# Altium schematic sheet [03] - ARDUINO NANO 33 IOT.SchDoc
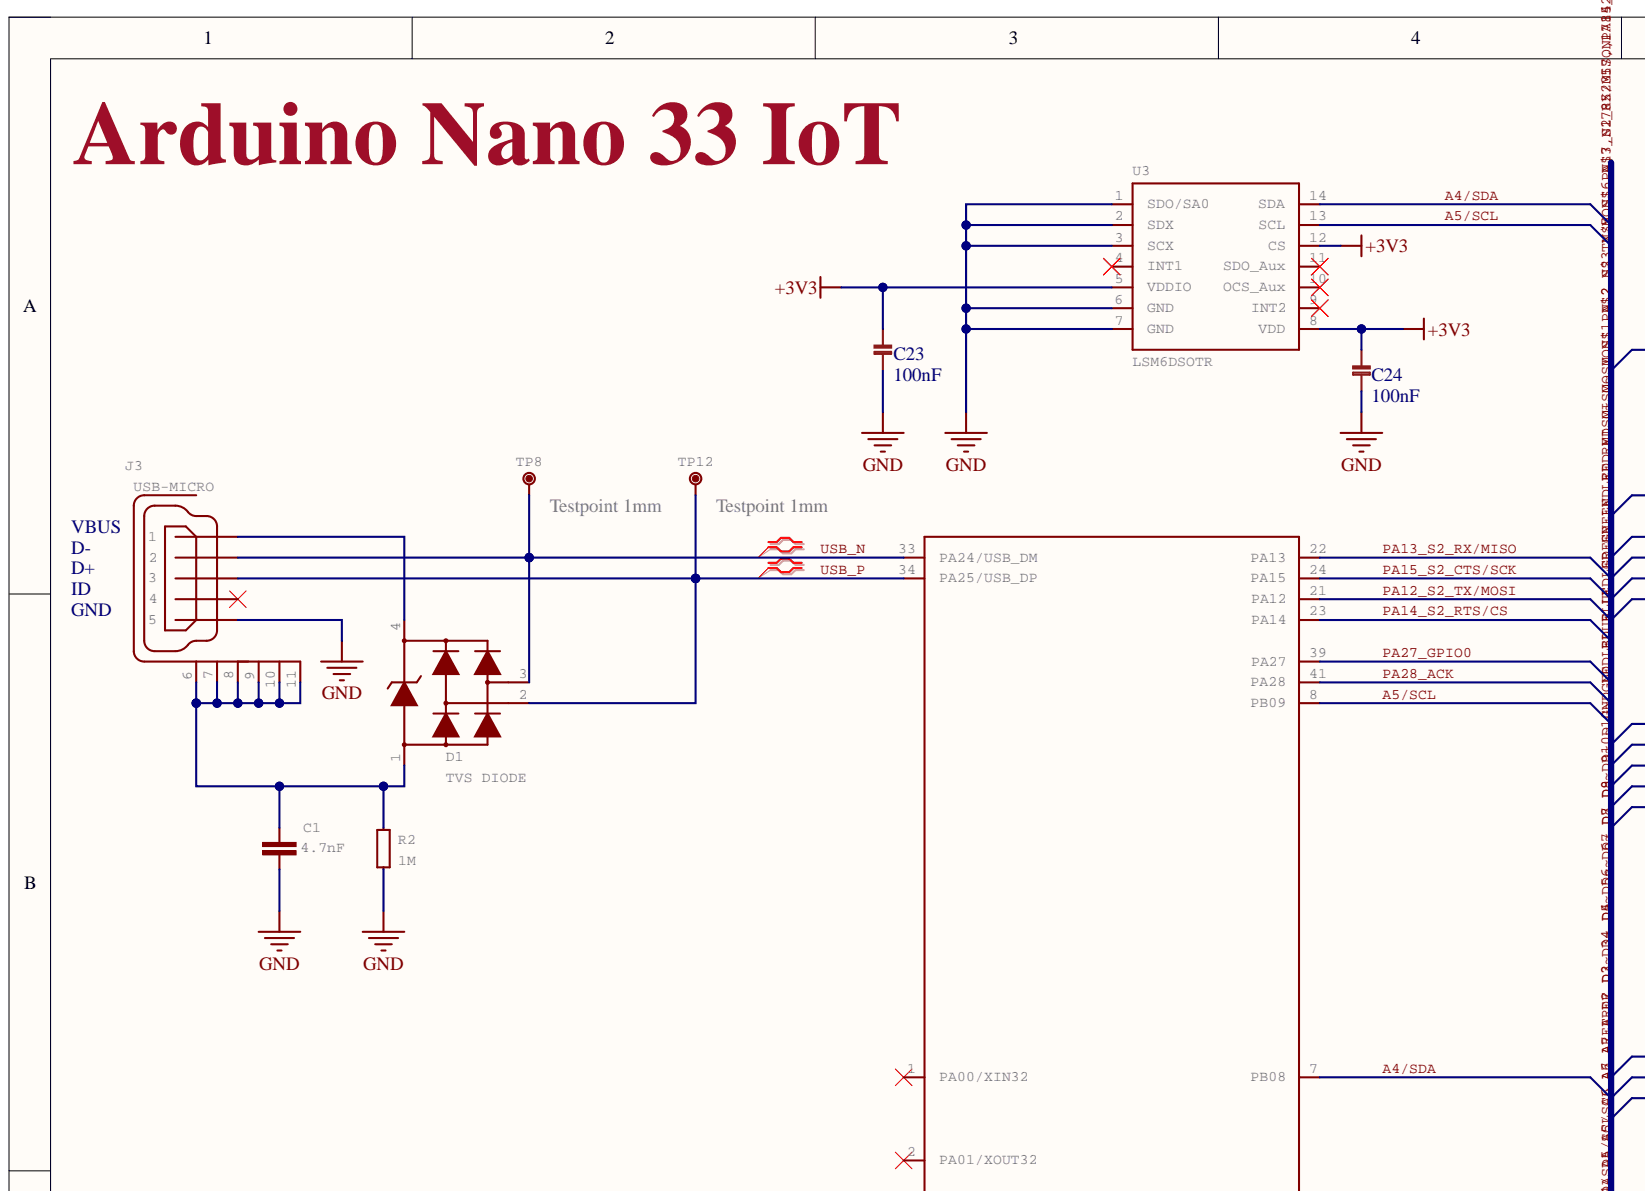
[diagram p0: [03] - ARDUINO NANO 33 IOT.SchDoc, top left]
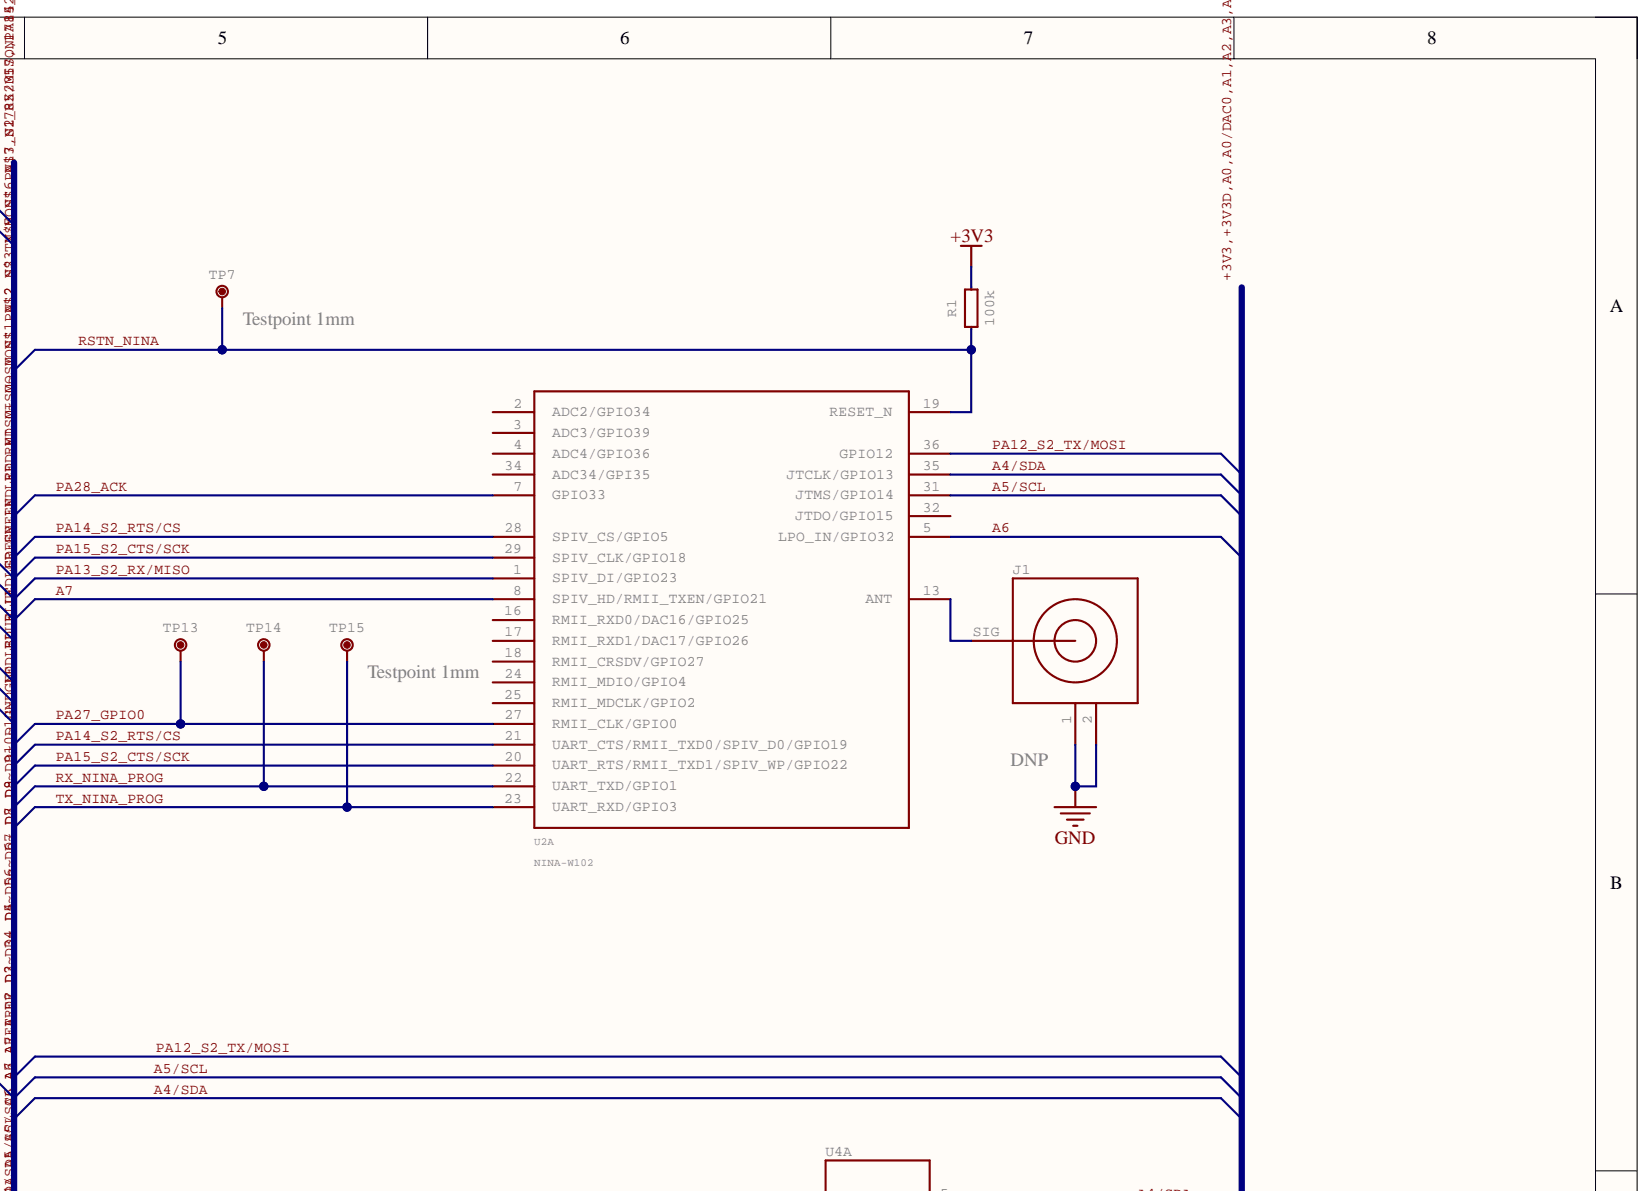
[diagram p1: [03] - ARDUINO NANO 33 IOT.SchDoc, top right]
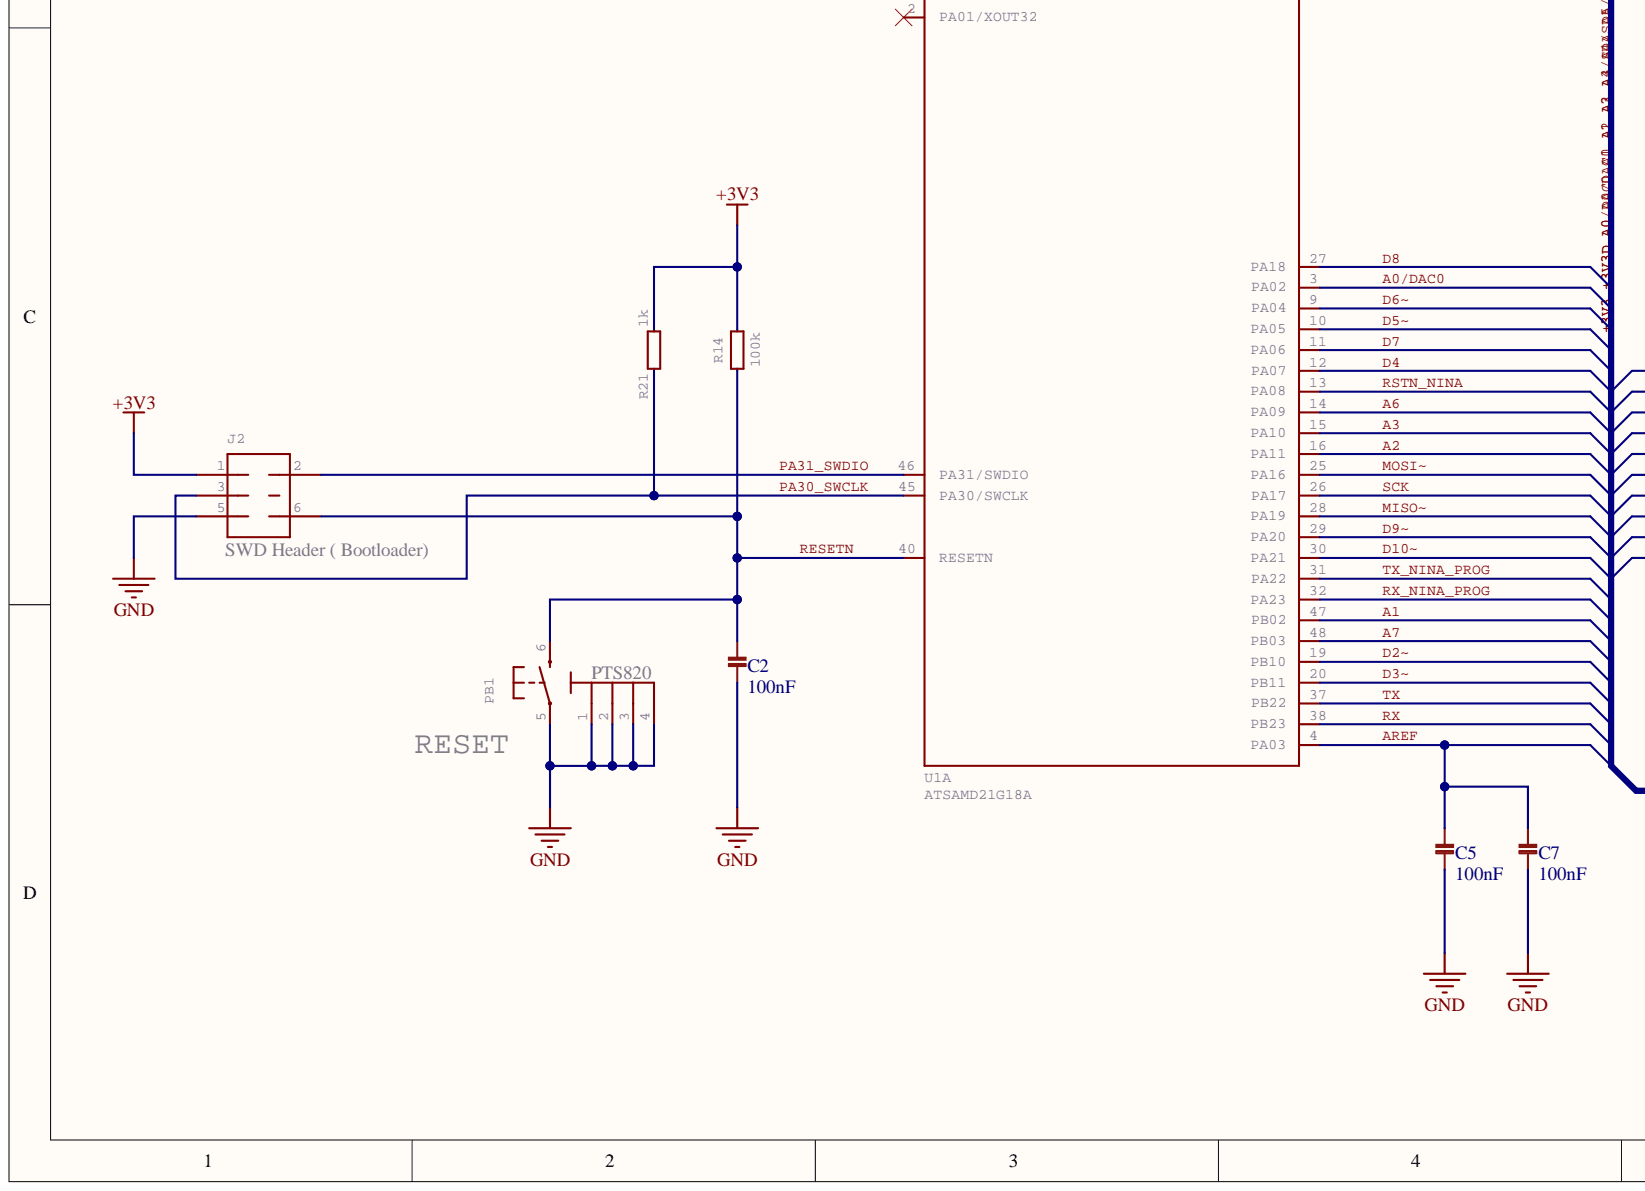
[diagram p2: [03] - ARDUINO NANO 33 IOT.SchDoc, bottom left]
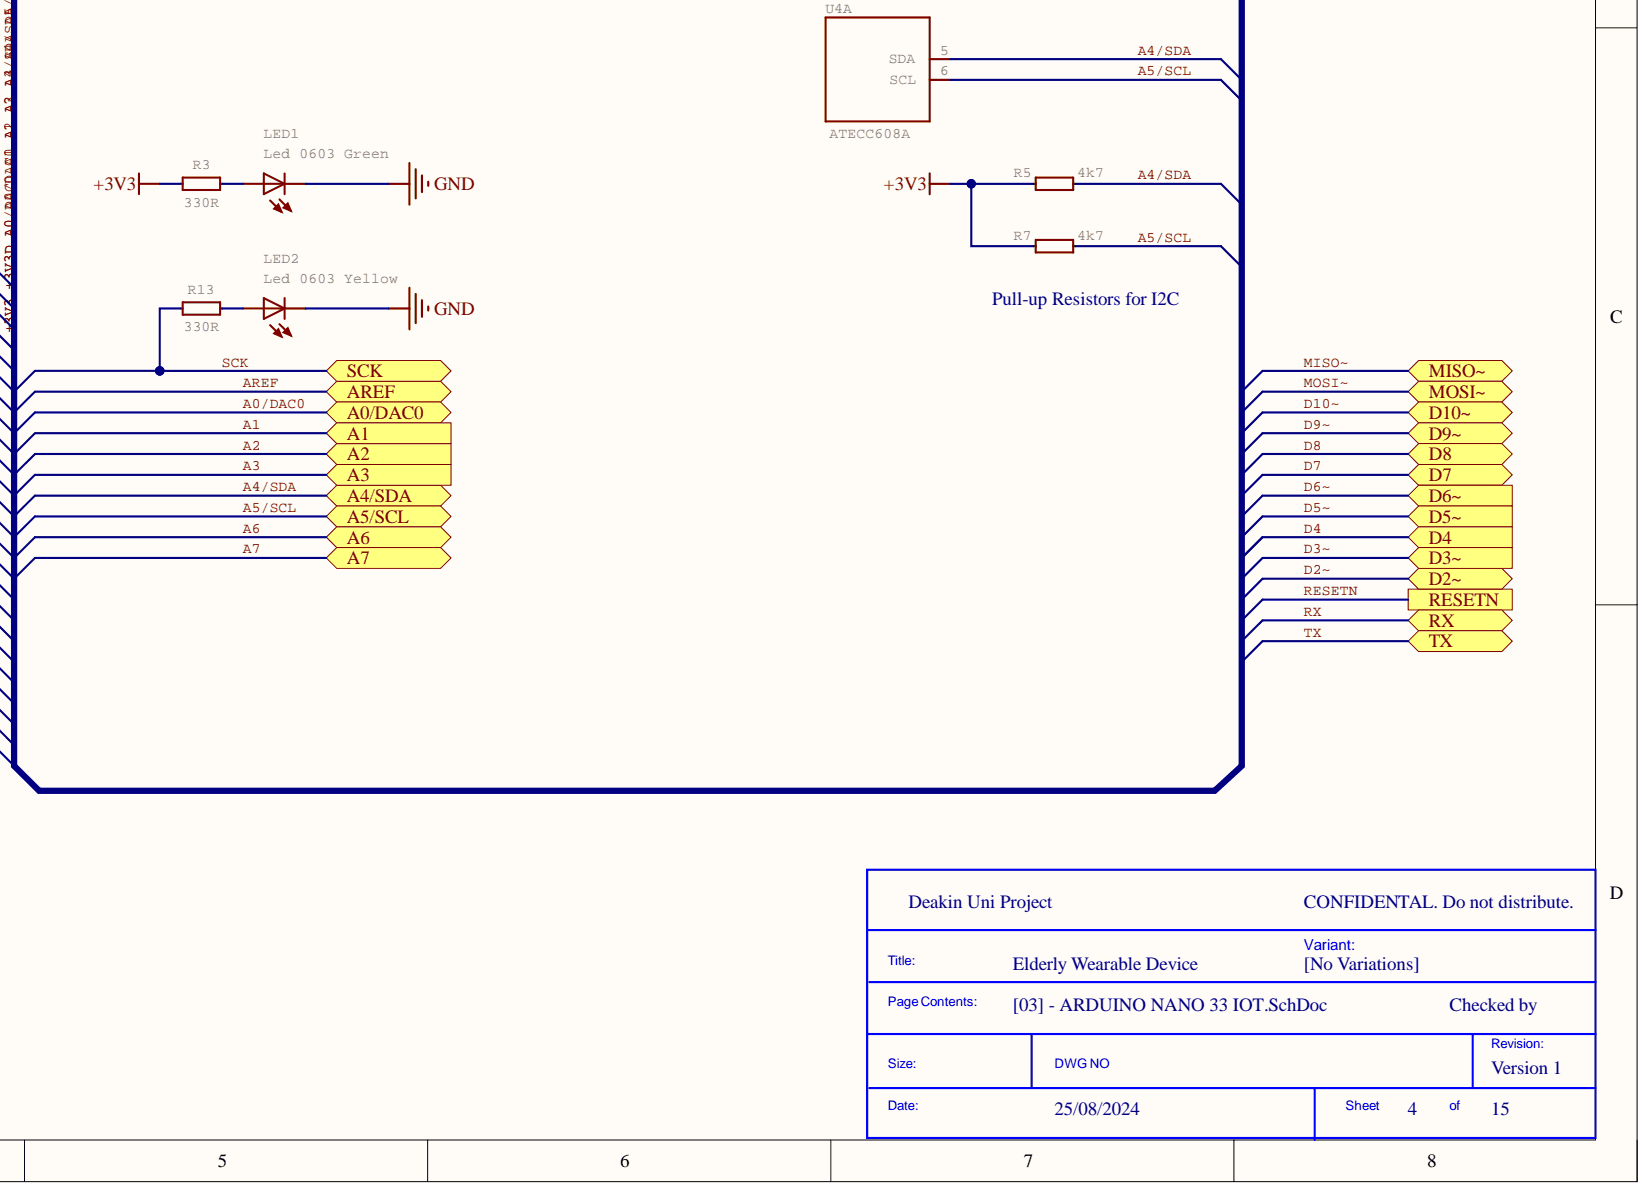
[diagram p3: [03] - ARDUINO NANO 33 IOT.SchDoc, bottom right]

## Parts
C1  4.7nF  [C_0805]
C2  100nF  [C_0402]
C5  100nF  [C_0402]
C7  100nF  [C_0402]
C23  100nF  [C_0402]
C24  100nF  [C_0402]
D1  TVS DIODE  [Tvs_Diode]
J1  DNP  [Antenna]  (no BOM)
J2  SWD Header ( Bootloader)  [SWD Header ( Bootloader)]
J3  USB-MICRO  [USB MIRCO]
LED1  Led 0603 Green  Package: 0603  [LED_0603_GREEN]
LED2  Led 0603 Yellow  Package: 0603  [LED_0603_YELLOW]
PB1  PTS820  [Tactile Switch]
R1  100k  [R_0402]
R2  1M  [R_0402]
R3  330R  [R_0402]
R5  4k7  [R_0402]
R7  4k7  [R_0402]
R13  330R  [R_0402]
R14  100k  [R_0402]
R21  1k  [R_0402]
TP7  Testpoint 1mm  [Testpoint_1mm]
TP8  Testpoint 1mm  [Testpoint_1mm]
TP12  Testpoint 1mm  [Testpoint_1mm]
TP13  Testpoint 1mm  [Testpoint_1mm]
TP14  Testpoint 1mm  [Testpoint_1mm]
TP15  Testpoint 1mm  [Testpoint_1mm]
U1  ATSAMD21G18A  [ATSAMD21G18A]  (part A)
U2  NINA-W102  [NINA-W102-00B]  (part A)
U3  LSM6DSOTR  [LSM6DSOTR]
U4  ATECC608A  [ATECC608A]  (part A)

## Ports
A0/DAC0, A1, A2, A3, A4/SDA, A5/SCL, A6, A7, AREF, D2~, D3~, D4, D5~, D6~, D7, D8, D9~, D10~, MISO~, MOSI~, RESETN, RX, SCK, TX

## Nets
+3V3: C23.2 C24.2 J2.1 R1.2 R3.1 R5.1 R7.1 R14.2 R21.2 U3.5(VDDIO) U3.8(VDD) U3.12(CS)
GND: C1.2 C2.1 C5.1 C7.1 C23.1 C24.1 J1.1 J1.2 J2.5 J3.5 LED1.C LED2.C PB1.1 PB1.2 PB1.3 PB1.4 PB1.5 R2.1 U3.1(SDO/SA0) U3.2(SDX) U3.3(SCX) U3.6(GND) U3.7(GND)
A0/DAC0: U1.3(PA02) ; port A0/DAC0
A1: U1.47(PB02) ; port A1
A2: U1.16(PA11) ; port A2
A3: U1.15(PA10) ; port A3
A4/SDA: R5.2 U1.7(PB08) U2.35(JTCLK/GPIO13) U3.14(SDA) U4.5(SDA) ; port A4/SDA
A5/SCL: R7.2 U1.8(PB09) U2.31(JTMS/GPIO14) U3.13(SCL) U4.6(SCL) ; port A5/SCL
A6: U1.14(PA09) U2.5(LPO_IN/GPIO32) ; port A6
A7: U1.48(PB03) U2.8(SPIV_HD/RMII_TXEN/GPIO21) ; port A7
AREF: C5.2 C7.2 U1.4(PA03) ; port AREF
D2~: U1.19(PB10) ; port D2~
D3~: U1.20(PB11) ; port D3~
D4: U1.12(PA07) ; port D4
D5~: U1.10(PA05) ; port D5~
D6~: U1.9(PA04) ; port D6~
D7: U1.11(PA06) ; port D7
D8: U1.27(PA18) ; port D8
D9~: U1.29(PA20) ; port D9~
D10~: U1.30(PA21) ; port D10~
MISO~: U1.28(PA19) ; port MISO~
MOSI~: U1.25(PA16) ; port MOSI~
PA12_S2_TX/MOSI: U1.21(PA12) U2.36(GPIO12)
PA13_S2_RX/MISO: U1.22(PA13) U2.1(SPIV_DI/GPIO23)
PA14_S2_RTS/CS: U1.23(PA14) U2.21(UART_CTS/RMII_TXD0/SPIV_D0/GPIO19) U2.28(SPIV_CS/GPIO5)
PA15_S2_CTS/SCK: U1.24(PA15) U2.20(UART_RTS/RMII_TXD1/SPIV_WP/GPIO22) U2.29(SPIV_CLK/GPIO18)
PA27_GPIO0: TP13.X U1.39(PA27) U2.27(RMII_CLK/GPIO0)
PA28_ACK: U1.41(PA28) U2.7(GPIO33)
PA30_SWCLK: J2.3 R21.1 U1.45(PA30/SWCLK)
PA31_SWDIO: J2.2 U1.46(PA31/SWDIO)
RESETN: C2.2 J2.6 PB1.6 R14.1 U1.40(RESETN) ; port RESETN
RSTN_NINA: R1.1 TP7.X U1.13(PA08) U2.19(RESET_N)
RX: U1.38(PB23) ; port RX
RX_NINA_PROG: TP14.X U1.32(PA23) U2.22(UART_TXD/GPIO1)
SCK: R13.1 U1.26(PA17) ; port SCK
TX: U1.37(PB22) ; port TX
TX_NINA_PROG: TP15.X U1.31(PA22) U2.23(UART_RXD/GPIO3)
USB_N: D1.3 J3.2 TP8.X U1.33(PA24/USB_DM)
USB_P: D1.2 J3.3 TP12.X U1.34(PA25/USB_DP)
NetC1_1: C1.1 D1.1 J3.6 J3.7 J3.8 J3.9 J3.10 J3.11 R2.2
NetD1_4: D1.4 J3.1
NetJ1_SIG: J1.SIG U2.13(ANT)
NetJ3_4: J3.4
NetLED1_A: LED1.A R3.2
NetLED2_A: LED2.A R13.2
NetU1_1: U1.1(PA00/XIN32)
NetU1_2: U1.2(PA01/XOUT32)
NetU2_2: U2.2(ADC2/GPIO34)
NetU2_3: U2.3(ADC3/GPIO39)
NetU2_4: U2.4(ADC4/GPIO36)
NetU2_16: U2.16(RMII_RXD0/DAC16/GPIO25)
NetU2_17: U2.17(RMII_RXD1/DAC17/GPIO26)
NetU2_18: U2.18(RMII_CRSDV/GPIO27)
NetU2_24: U2.24(RMII_MDIO/GPIO4)
NetU2_25: U2.25(RMII_MDCLK/GPIO2)
NetU2_32: U2.32(JTDO/GPIO15)
NetU2_34: U2.34(ADC34/GPI35)
NetU3_4: U3.4(INT1)
NetU3_9: U3.9(INT2)
NetU3_10: U3.10(OCS_Aux)
NetU3_11: U3.11(SDO_Aux)
+3V3,+3V3D,A0,A0/DAC0,A1,A2,A3,A4/SDA,A5/SCL,A6,A7,AREF,D2,D3~,D4,D5~,D6~,D7,D8,D9~,D10~,GND,LED_BLUE,LED_GREEN,LED_RED,MISO~,MOSI,PA12_S2_TX/MOSI,PA13_S2_RX/MISO,PA14_S2_RTS/CS,PA15_S2_CTS/SCK,PA22_TC4-W0,PA23_TC4-W1,PA27_GPIO0,PA28_ACK,PA30_SWCLK,PA31_SWDIO,PB08_RST,PB11_TCC0-W5,RESET#,RESETN,RSTN_NINA,RX,RX_NINA_PROG,SCK,TX,TX_NINA_PROG,USB_N,USB_P,VIN:

## Library data of the parts
C1 = C_0805_4.7nF: SMD Capacitor; MANUFACTURER: Murata Electronics; Manufacturer Part Number: GRM2165C1H472JA01D; ALL GOOD: OK_DONE; Component Kind: Standard; Package: 0805; Supplier: Digi-Key; Supplier Part Number: 490-3044-1-ND; Library Name: Elderly_Wearable_Device.SCHLIB
C2 C5 C7 C23 C24 = C_0402_100nF: SMD Capacitor; Manufacturer: Murata Electronics; Manufacturer Part Number: GRM155R71C104KA88J; ALL GOOD: OK_DONE; Component Kind: Standard; Package: 0402; Supplier: Digi-Key; Supplier Part Number: 490-6328-1-ND; Library Name: Elderly_Wearable_Device.SCHLIB
D1 = TVS DIODE: USB ESD protection, TVS DIODE; MANUFACTURER: Nexperia USA Inc.; Manufacturer Part Number: PRTR5V0U2X,215; ALL GOOD: OK_DONE; Component Kind: Standard; Package: SOT-143B; Supplier: Digi-Key; Supplier Part Number: 1727-3884-1-ND; Library Name: Elderly_Wearable_Device.SCHLIB
J1 = Antenna: U.FL, Ultra Miniature Coaxial Connector Receptacle, Male Pin 50 Ohm Surface Mount Solder; Manufacturer Part Number: UFL; ALL GOOD: OK_DONE; MANUFACTURER: DNP; Package: NO_CREAM; Supplier: DNP; Supplier Part Number: DNP; Library Name: Elderly_Wearable_Device.SCHLIB
J2 = SWD Header ( Bootloader): PIN HEADER for bootloading; MANUFACTURER: NA; ALL GOOD: OK_DONE; Component Kind: Standard; Manufacturer Part Number: NA; Package: PINHD-2X03(NO4); Supplier: NA; Supplier Part Number: NA; Library Name: Elderly_Wearable_Device.SCHLIB
J3 = USB-MICRO: USB - micro AB USB 2.0 Receptacle Connector 5 Position Surface Mount, Right Angle, Horizontal; MANUFACTURER: Hirose Electric Co Ltd; Manufacturer Part Number: ZX62-AB-5PA(31); ALL GOOD: OK_DONE; Component Kind: Standard; Package: USB-MICRO; Supplier: Digi-Key; Supplier Part Number: H125279CT-ND; Library Name: Elderly_Wearable_Device.SCHLIB
LED1 = Led 0603 Green: MANUFACTURER: Broadcom Limited; Manufacturer Part Number: HSMG-C190; ALL GOOD: OK_DONE; Component Kind: Standard; Description: Led 0603 Green; Supplier: Digi-Key; Supplier Part Number: 516-1425-1-ND; Library Name: Elderly_Wearable_Device.SCHLIB
LED2 = Led 0603 Yellow: MANUFACTURER: Broadcom Limited; Manufacturer Part Number: HSMY-C190; ALL GOOD: OK_DONE; Component Kind: Standard; Description: Led 0603 Yellow; Supplier: Digi-Key; Supplier Part Number: 516-1424-1-ND; Library Name: Elderly_Wearable_Device.SCHLIB
PB1 = Tactile Switch: Tactile Switch SPST-NO Top Actuated Surface Mount; MANUFACTURER: C&K; Manufacturer Part Number: PTS820 J25K SMTR LFS; ALL GOOD: OK_DONE; Component Kind: Standard; Package: PTS820; Supplier: Digi-Key; Supplier Part Number: CKN10897CT-ND; Library Name: Elderly_Wearable_Device.SCHLIB
R1 R14 = R_0402_100k: SMD Resistor; MANUFACTURER: Yageo; Manufacturer Part Number: RC0402FR-07100KL; ALL GOOD: OK_DONE; Component Kind: Standard; Package: 0402; Supplier: Digi-Key; Supplier Part Number: 311-100KLRCT-ND; Library Name: Elderly_Wearable_Device.SCHLIB
R2 = R_0402_1M: SMD Resistor; MANUFACTURER: Yageo; Manufacturer Part Number: RC0402FR-071ML; ALL GOOD: OK_DONE; Component Kind: Standard; Package: 0402; Supplier: Digi-Key; Supplier Part Number: 311-1.00MLRCT-ND; Library Name: Elderly_Wearable_Device.SCHLIB
R3 R13 = R_0402_330R: SMD Resistor; MANUFACTURER: Yageo; Manufacturer Part Number: RC0402FR-07330RL; ALL GOOD: OK_DONE; Component Kind: Standard; Package: 0402; Supplier: Digi-Key; Supplier Part Number: 311-330LRCT-ND; Library Name: Elderly_Wearable_Device.SCHLIB
R5 R7 = R_0402_4.7k: SMD Resistor; Manufacturer: Yageo; Manufacturer Part Number: RC0402FR-074K7L; ALL GOOD: OK_DONE; Component Kind: Standard; Package: 0402; Supplier: Digi-Key; Supplier Part Number: 311-4.7KLRCT-ND; Library Name: Elderly_Wearable_Device.SCHLIB
R21 = R_0402_1k: SMD Resistor; MANUFACTURER: Yageo; Manufacturer Part Number: RC0402FR-071KL; ALL GOOD: OK_DONE; Component Kind: Standard; Package: 0402; Supplier: Digi-Key; Supplier Part Number: 311-1.00KLRCT-ND; Library Name: Elderly_Wearable_Device.SCHLIB
TP7 TP8 TP12-TP15 = Testpoint 1mm: MANUFACTURER: NA; ALL GOOD: OK_DONE; Component Kind: Standard; Description: Testpoint 1mm; Manufacturer Part Number: NA; Package: TP-1.00MM; Supplier: NA; Supplier Part Number: NA; Library Name: Elderly_Wearable_Device.SCHLIB
U1 = ATSAMD21G18A: ARMÂ® CortexÂ®-M0+ SAM D21G Microcontroller IC 32-Bit 48MHz 256KB (256K x 8) FLASH 48-TQFP (7x7) 48QFN; MANUFACTURER: Microchip Technology; Manufacturer Part Number: ATSAMD21G18A-MU; ALL GOOD: OK_DONE; Component Kind: Standard; Package: 48-VFQFN Exposed Pad; Supplier: Digi-Key; Supplier Part Number: ATSAMD21G18A-MU-ND; Library Name: Elderly_Wearable_Device.SCHLIB
U2 = NINA-W102-00B: ESP32 WiFi/BLE module; MANUFACTURER: u-blox; ALL GOOD: OK_DONE; Component Kind: Standard; Manufacturer Part Number: NINA-W102-00B; Package: 36-SMD Module; Supplier: Digi-Key; Supplier Part Number: 672-NINA-W102-00BCT-ND; Library Name: Elderly_Wearable_Device.SCHLIB
U3 = LSM6DSOTR: 6DOF IMU; MANUFACTURER: STMicroelectronics; ALL GOOD: OK_DONE; Component Kind: Standard; Manufacturer Part Number: LSM6DSOTR; Package: 14-VFLGA Module; Supplier: Digi-Key; Supplier Part Number: 497-18181-1-ND; Library Name: Elderly_Wearable_Device.SCHLIB
U4 = ATECC608A: Secure authentication and product validation device, EEPROM, I2C, 2.0 - 5.5V; MANUFACTURER: Microchip Technology; Manufacturer Part Number: ATECC608A-MAHDA-T; ALL GOOD: OK_DONE; Component Kind: Standard; Package: 8-UFDFN Exposed Pad; Supplier: Digi-Key; Supplier Part Number: ATECC608A-MAHDA-TCT-ND; Library Name: Elderly_Wearable_Device.SCHLIB
